# Altium schematic sheet 电磁板.SchDoc
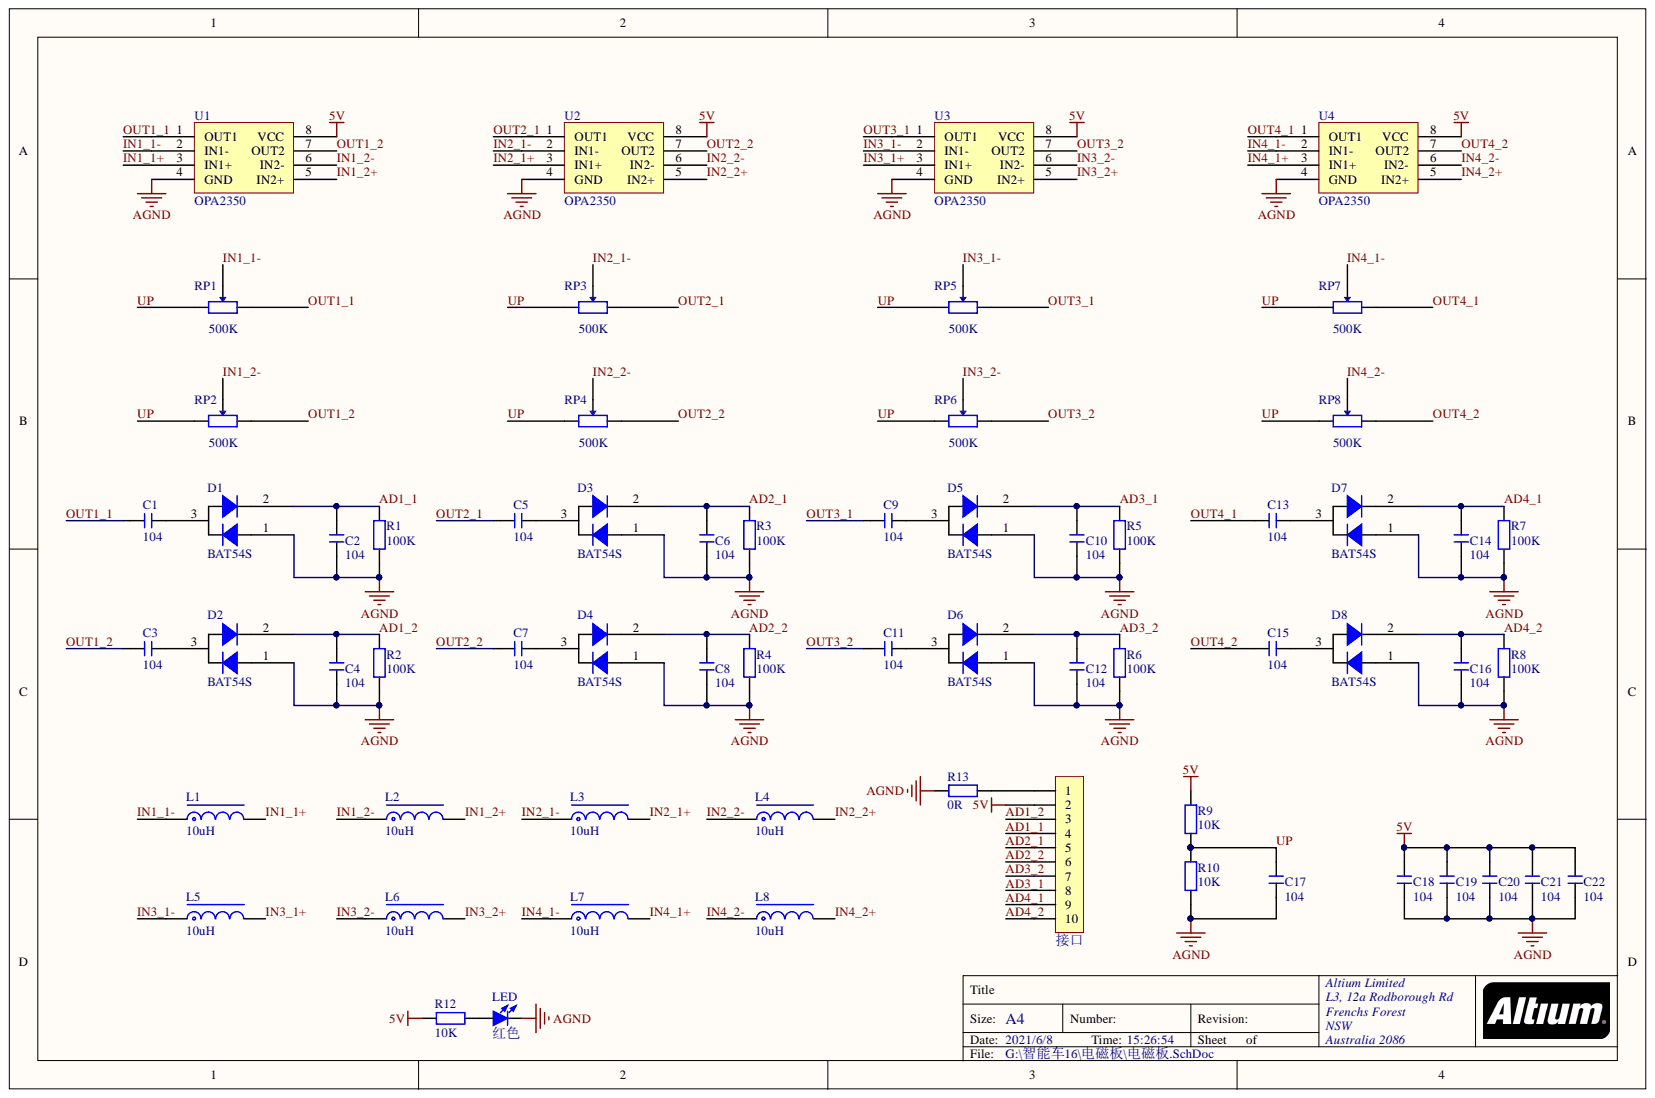
[diagram p0: 电磁板.SchDoc]

## Parts
C1  104  [C 0603_M]
C2  104  [C 0603_M]
C3  104  [C 0603_M]
C4  104  [C 0603_M]
C5  104  [C 0603_M]
C6  104  [C 0603_M]
C7  104  [C 0603_M]
C8  104  [C 0603_M]
C9  104  [C 0603_M]
C10  104  [C 0603_M]
C11  104  [C 0603_M]
C12  104  [C 0603_M]
C13  104  [C 0603_M]
C14  104  [C 0603_M]
C15  104  [C 0603_M]
C16  104  [C 0603_M]
C17  104  [C 0603_M]
C18  104  [C 0603_M]
C19  104  [C 0603_M]
C20  104  [C 0603_M]
C21  104  [C 0603_M]
C22  104  [C 0603_M]
D1  BAT54S  [SOT23-3L]
D2  BAT54S  [SOT23-3L]
D3  BAT54S  [SOT23-3L]
D4  BAT54S  [SOT23-3L]
D5  BAT54S  [SOT23-3L]
D6  BAT54S  [SOT23-3L]
D7  BAT54S  [SOT23-3L]
D8  BAT54S  [SOT23-3L]
L1  10uH  [PK0406]
L2  10uH  [PK0406]
L3  10uH  [PK0406]
L4  10uH  [PK0406]
L5  10uH  [PK0406]
L6  10uH  [PK0406]
L7  10uH  [PK0406]
L8  10uH  [PK0406]
LED  红色  [LED 0805G]
R1  100K  [R 0603_M]
R2  100K  [R 0603_M]
R3  100K  [R 0603_M]
R4  100K  [R 0603_M]
R5  100K  [R 0603_M]
R6  100K  [R 0603_M]
R7  100K  [R 0603_M]
R8  100K  [R 0603_M]
R9  10K  [R 0603_M]
R10  10K  [R 0603_M]
R12  10K  [R 0603_M]
R13  0R  [R 0603_M]
RP1  500K  [3296W]
RP2  500K  [3296W]
RP3  500K  [3296W]
RP4  500K  [3296W]
RP5  500K  [3296W]
RP6  500K  [3296W]
RP7  500K  [3296W]
RP8  500K  [3296W]
U1  OPA2350  [SOP8_M]
U2  OPA2350  [SOP8_M]
U3  OPA2350  [SOP8_M]
U4  OPA2350  [SOP8_M]
接口  FPC1.0-10P  [FPC0.5 2H-WS-10P]  (value not drawn)

## Nets
5V: C18.2 C19.2 C20.2 C21.2 C22.2 R9.2 R12.2 U1.8(VCC) U2.8(VCC) U3.8(VCC) U4.8(VCC) 接口.2
AGND: C2.1 C4.1 C6.1 C8.1 C10.1 C12.1 C14.1 C16.1 C17.1 C18.1 C19.1 C20.1 C21.1 C22.1 D1.1 D2.1 D3.1 D4.1 D5.1 D6.1 D7.1 D8.1 LED.2 R1.1 R2.1 R3.1 R4.1 R5.1 R6.1 R7.1 R8.1 R10.1 R13.2 U1.4(GND) U2.4(GND) U3.4(GND) U4.4(GND)
AD1_1: C2.2 D1.2 R1.2 接口.4
AD1_2: C4.2 D2.2 R2.2 接口.3
AD2_1: C6.2 D3.2 R3.2 接口.5
AD2_2: C8.2 D4.2 R4.2 接口.6
AD3_1: C10.2 D5.2 R5.2 接口.8
AD3_2: C12.2 D6.2 R6.2 接口.7
AD4_1: C14.2 D7.2 R7.2 接口.9
AD4_2: C16.2 D8.2 R8.2 接口.10
IN1_1+: L1.2 U1.3(IN1+)
IN1_1-: L1.1 RP1.2 U1.2(IN1-)
IN1_2+: L2.2 U1.5(IN2+)
IN1_2-: L2.1 RP2.2 U1.6(IN2-)
IN2_1+: L3.2 U2.3(IN1+)
IN2_1-: L3.1 RP3.2 U2.2(IN1-)
IN2_2+: L4.2 U2.5(IN2+)
IN2_2-: L4.1 RP4.2 U2.6(IN2-)
IN3_1+: L5.2 U3.3(IN1+)
IN3_1-: L5.1 RP5.2 U3.2(IN1-)
IN3_2+: L6.2 U3.5(IN2+)
IN3_2-: L6.1 RP6.2 U3.6(IN2-)
IN4_1+: L7.2 U4.3(IN1+)
IN4_1-: L7.1 RP7.2 U4.2(IN1-)
IN4_2+: L8.2 U4.5(IN2+)
IN4_2-: L8.1 RP8.2 U4.6(IN2-)
OUT1_1: C1.1 RP1.3 U1.1(OUT1)
OUT1_2: C3.1 RP2.3 U1.7(OUT2)
OUT2_1: C5.1 RP3.3 U2.1(OUT1)
OUT2_2: C7.1 RP4.3 U2.7(OUT2)
OUT3_1: C9.1 RP5.3 U3.1(OUT1)
OUT3_2: C11.1 RP6.3 U3.7(OUT2)
OUT4_1: C13.1 RP7.3 U4.1(OUT1)
OUT4_2: C15.1 RP8.3 U4.7(OUT2)
UP: C17.2 R9.1 R10.2 RP1.1 RP2.1 RP3.1 RP4.1 RP5.1 RP6.1 RP7.1 RP8.1
NetC1_2: C1.2 D1.3
NetC3_2: C3.2 D2.3
NetC5_2: C5.2 D3.3
NetC7_2: C7.2 D4.3
NetC9_2: C9.2 D5.3
NetC11_2: C11.2 D6.3
NetC13_2: C13.2 D7.3
NetC15_2: C15.2 D8.3
NetLED_1: LED.1 R12.1
NetR13_1: R13.1 接口.1

## Library data of the parts
C1-C22 = C: 无极性贴片电容; 版权归属: 源创客; BOM名称: 无极性贴片电容; Component Kind: Standard; Library Name: 3.1   - 电阻-电容-电感.SchLib; 学习资源: www.ooocke.com
L1-L8 = L-PK: 工字电感; 版权归属: 源创客; BOM名称: 工字电感; Component Kind: Standard; Library Name: 3.1   - 电阻-电容-电感.SchLib; 学习资源: www.ooocke.com
LED = LED-SMD: 贴片LED; 版权归属: 源创客; BOM名称: 贴片LED; Component Kind: Standard; Library Name: 9.1   - LED.SchLib; 学习资源: www.ooocke.com
R1-R10 R12 R13 = R: 贴片电阻; 版权归属: 源创客; BOM名称: 贴片电阻; Component Kind: Standard; Library Name: 3.1   - 电阻-电容-电感.SchLib; 学习资源: www.ooocke.com
RP1-RP8 = RP-ID: 插件单联电位器; BOM名称: 插件电位器; 版权归属: 源创客; Component Kind: Standard; Library Name: 3.1   - 电阻-电容-电感.SchLib; 学习资源: www.ooocke.com
接口 = FPC-10P: FPC连接器; 版权归属: 源创客; BOM名称: FPC连接器; Component Kind: Standard; Library Name: 4.14 - FPC连接器.SchLib; 学习资源: www.ooocke.com
D1-D8 = BAT54S: Component Kind: Standard; Library Name: 智能车.SchLib
U1-U4 = OPA2350: Component Kind: Standard; Library Name: 智能车.SchLib
